# Altium schematic sheet LED_SW_BUT.SchDoc(LED_SW_BUT)
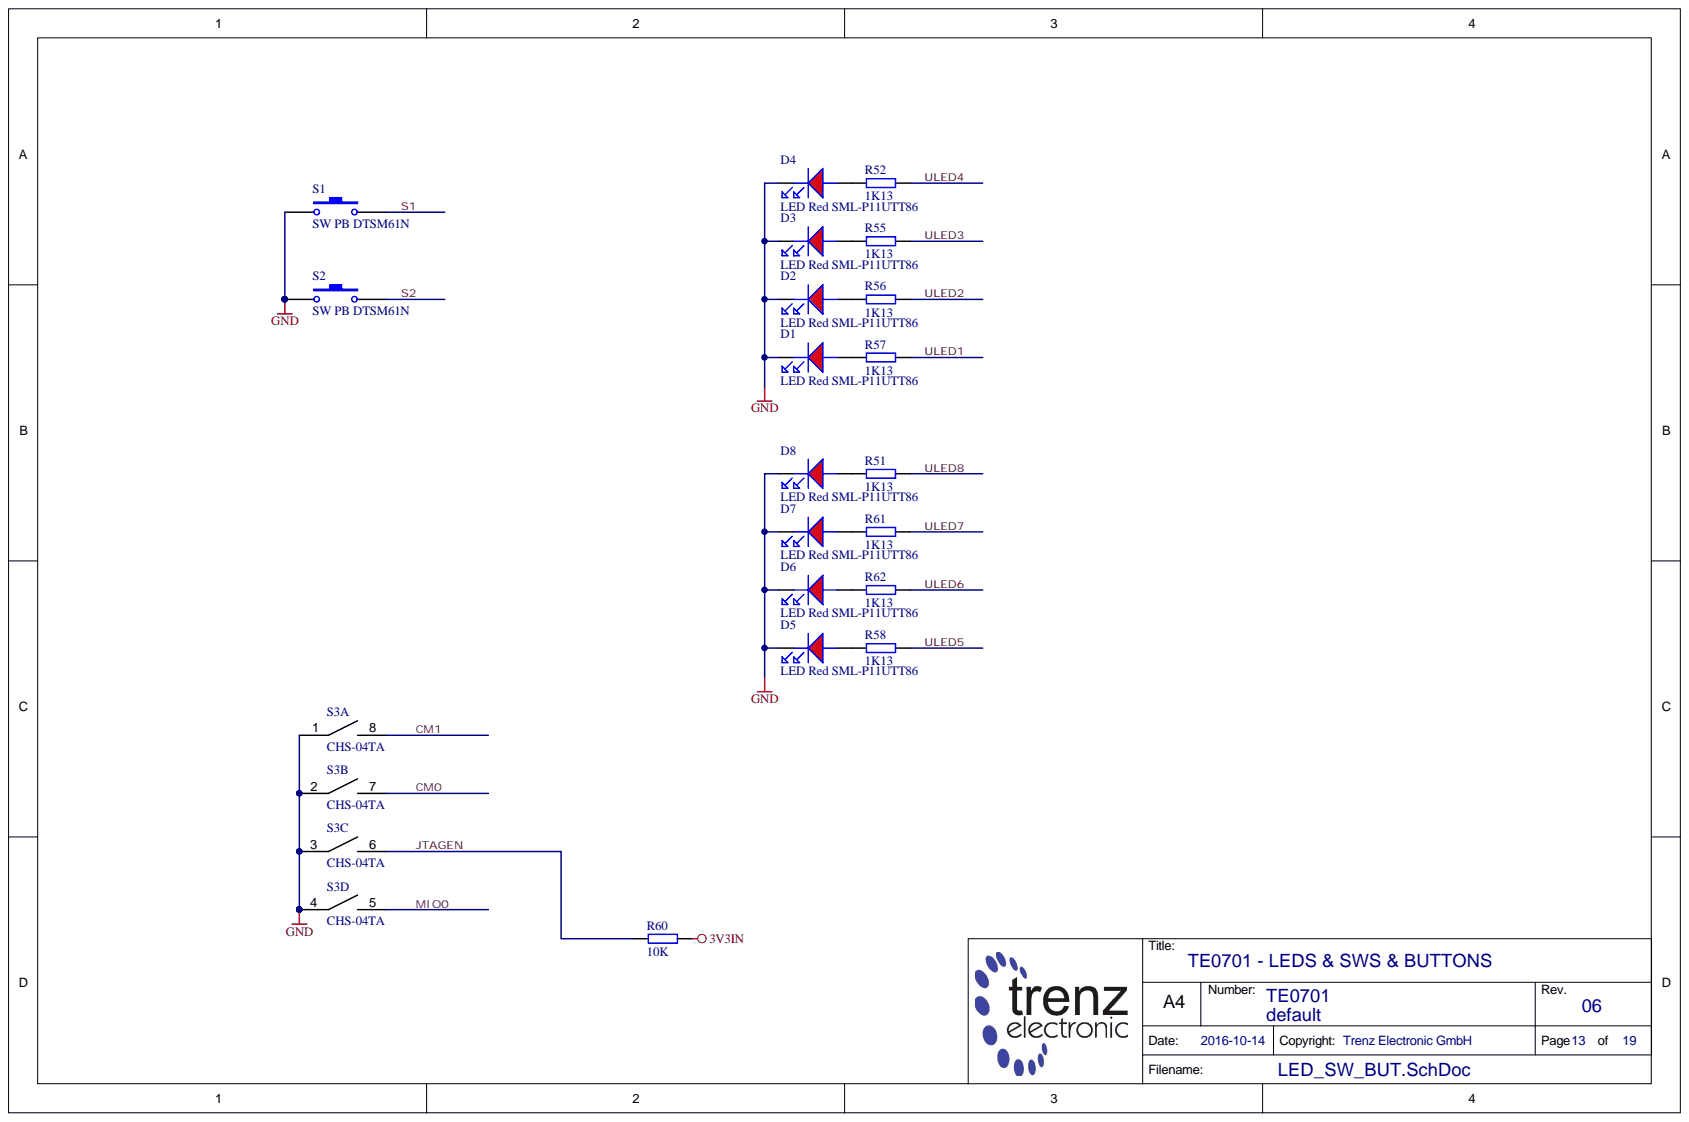
[diagram p0: LED_SW_BUT.SchDoc]

## Parts
D1  LED Red SML-P11UTT86  Database Table Name: LED  H-ART: SML-P11UTT86  [LEDC1006X25N_RED]
D2  LED Red SML-P11UTT86  Database Table Name: LED  H-ART: SML-P11UTT86  [LEDC1006X25N_RED]
D3  LED Red SML-P11UTT86  Database Table Name: LED  H-ART: SML-P11UTT86  [LEDC1006X25N_RED]
D4  LED Red SML-P11UTT86  Database Table Name: LED  H-ART: SML-P11UTT86  [LEDC1006X25N_RED]
D5  LED Red SML-P11UTT86  Database Table Name: LED  H-ART: SML-P11UTT86  [LEDC1006X25N_RED]
D6  LED Red SML-P11UTT86  Database Table Name: LED  H-ART: SML-P11UTT86  [LEDC1006X25N_RED]
D7  LED Red SML-P11UTT86  Database Table Name: LED  H-ART: SML-P11UTT86  [LEDC1006X25N_RED]
D8  LED Red SML-P11UTT86  Database Table Name: LED  H-ART: SML-P11UTT86  [LEDC1006X25N_RED]
R51  1K13  [RESC1005X40N]
R52  1K13  [RESC1005X40N]
R55  1K13  [RESC1005X40N]
R56  1K13  [RESC1005X40N]
R57  1K13  [RESC1005X40N]
R58  1K13  [RESC1005X40N]
R60  10K  [RESC1005X40N]
R61  1K13  [RESC1005X40N]
R62  1K13  [RESC1005X40N]
S1  SW PB DTSM61N  [DTSM61N]
S2  SW PB DTSM61N  [DTSM61N]
S3  CHS-04TA  [CHS-04TA]  (parts A,B,C,D)

## Nets
3V3IN: R60.1
GND: D1.K D2.K D3.K D4.K D5.K D6.K D7.K D8.K S1.2 S2.2 S3.1 S3.2 S3.3 S3.4
CM0: S3.7
CM1: S3.8
JTAGEN: R60.2 S3.6
MIO0: S3.5
S1: S1.1
S2: S2.1
ULED1: R57.2
ULED2: R56.2
ULED3: R55.2
ULED4: R52.2
ULED5: R58.2
ULED6: R62.2
ULED7: R61.2
ULED8: R51.2
NetD1_A: D1.A R57.1
NetD2_A: D2.A R56.1
NetD3_A: D3.A R55.1
NetD4_A: D4.A R52.1
NetD5_A: D5.A R58.1
NetD6_A: D6.A R62.1
NetD7_A: D7.A R61.1
NetD8_A: D8.A R51.1

## Library data of the parts
D1-D8 = 24212: LED Rot SML-P11UTT86 SMD; Mixed: SMT; Preferred: Yes; AN: 24212; Case: 1005 (0402); Component Kind: Standard; Library Name: TE.DbLib; HelpURL: B:\AD\adlib\datasheet\SML-P1
R51 R52 R55-R58 R61 R62 = 23160: WID SMD 1K13 1% 0,063W; Database Table Name: R_1005_0402; Mixed: SMT; Preferred: Yes; Value: 1.13K; AN: 23160; Case: 1005 (0402); Component Kind: Standard; Library Name: TE.DbLib; Technology: TK100; Tolerance: 1%
R60 = 22750: WID SMD 10K 1% 0,063W; Database Table Name: R_1005_0402; Mixed: SMT; Preferred: Yes; AN: 22750; Case: 1005 (0402); Component Kind: Standard; Library Name: TE.DbLib; Technology: TK100; Tolerance: 1%; Value: 10K
S1 S2 = 22596: Taster Miniatur Leiterplatte 1.6N; Database Table Name: PB_SW; Mixed: SMT; Preferred: Yes; AN: 22596; Component Kind: Standard; H-ART: DTSM61N; Library Name: TE.DbLib; Trenz-Stock: Yes; HelpURL: B:\AD\adlib\datasheet\DTSM
S3 = 23417: DIP Schalter 4 fach 1,27mm SMD; Database Table Name: PB_SW; Mixed: SMT; Preferred: Yes; AN: 23417; Component Kind: Standard; H-ART: CHS-04TA; Library Name: TE.DbLib; Trenz-Stock: Yes; HelpURL: B:\AD\adlib\datasheet\CHS
